# Altium schematic sheet ESD-OP.SchDoc(ESD-OP)
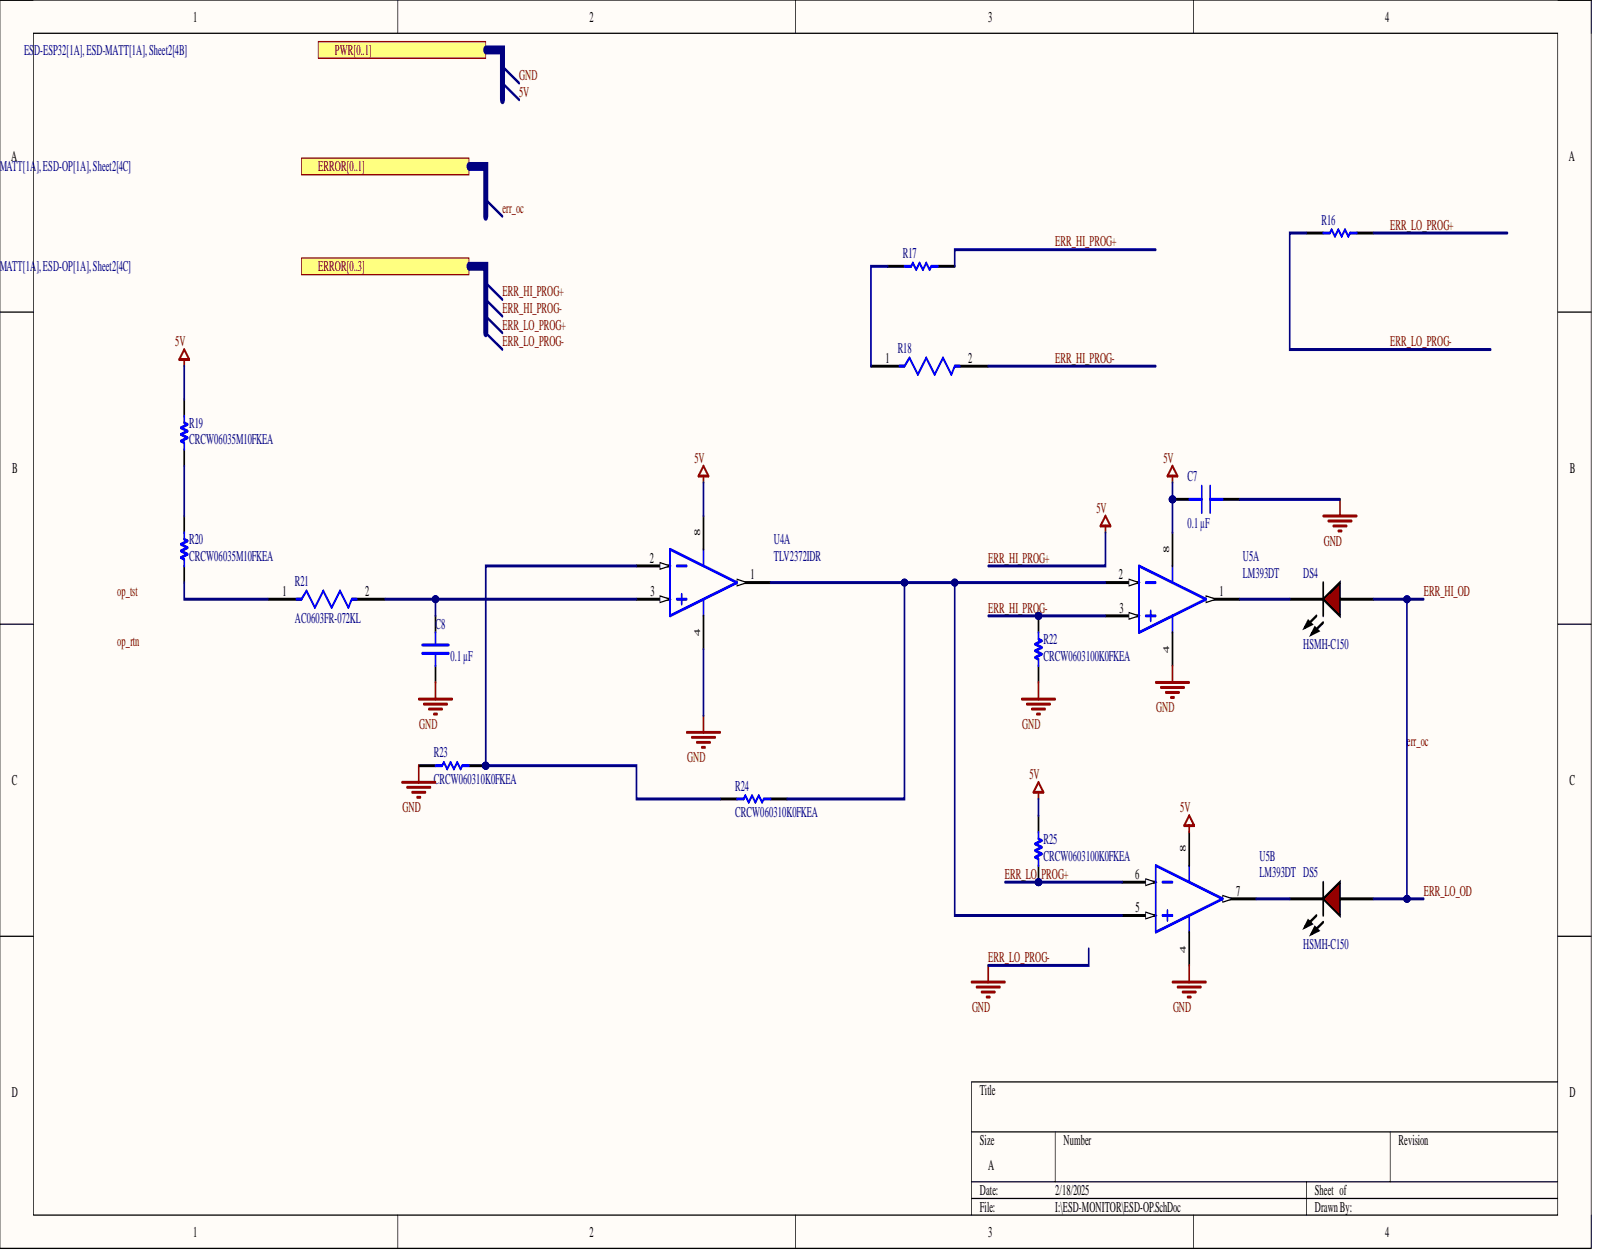
[diagram p0: ESD-OP.SchDoc]

## Parts
C7  0.1 µF  [CAP 0603_1608]
C8  0.1 µF  [CAP 0603_1608]
DS4  HSMH-C150  [AVAG-HSMX-C150_V]
DS5  HSMH-C150  [AVAG-HSMX-C150_V]
R16  CRCW060310K0FKEA  [RESC1609X50X30NL8T20]
R17  CRCW060310K0FKEA  [RESC1609X50X30NL8T20]
R18  AC0603FR-072KL  [FP-AC0603-IPC_B]
R19  CRCW06035M10FKEA  [RESC1609X50X30NL10T20]
R20  CRCW06035M10FKEA  [RESC1609X50X30NL10T20]
R21  AC0603FR-072KL  [FP-AC0603-IPC_B]
R22  CRCW0603100K0FKEA  [RESC1609X50X30NL8T20]
R23  CRCW060310K0FKEA  [RESC1609X50X30NL8T20]
R24  CRCW060310K0FKEA  [RESC1609X50X30NL8T20]
R25  CRCW0603100K0FKEA  [RESC1609X50X30NL8T20]
U4  TLV2372IDR  [D0008A_M]  (part A)
U5  LM393DT  [STM-SO-8_D_L]  (parts A,B)

## Ports
ERROR0, ERROR1, ERROR2, ERROR3, ERROR[0..1], ERROR[0..3], PWR0, PWR1, PWR[0..1]

## Nets
5V: C7.2 R17.2 R19.2 R25.1 U4.8 U5.8
ERR_HI_OD: DS4.1 DS5.1
ERR_HI_PROG-: R18.2 R22.1 U5.3
ERR_LO_PROG+: R16.2 R25.2 U5.6
ERR_LO_PROG-: C7.1 C8.1 R16.1 R22.2 R23.1 U4.4 U5.4
NetC8_2: C8.2 R21.2 U4.3
NetDS4_2: DS4.2 U5.1
NetDS5_2: DS5.2 U5.7
NetR17_1: R17.1 R18.1
NetR19_1: R19.1 R20.2
NetR20_1: R20.1 R21.1
NetR23_2: R23.2 R24.1 U4.2
NetR24_2: R24.2 U4.1 U5.2 U5.5
N00002_0: ; port ERROR[0..1], port ERROR[0..3]
N00002_1: ; port ERROR[0..1], port ERROR[0..3]
N00002_[0..1]: ; port ERROR[0..1]
N00006_2: ; port ERROR[0..3]
N00006_3: ; port ERROR[0..3]
N00006_[0..3]: ; port ERROR[0..3]
N00008_0: ; port PWR[0..1]
N00008_1: ; port PWR[0..1]
op_rtn:
op_tst:

## Library data of the parts
C7 C8 = C0603X104J5RACAUTO: CAP CER 0.1UF 50V X7R 0603; manufacturer: KEMET; Database Table Name: Capacitors - Ceramic - 0603; Tolerance: ±5%; Applications: Automotive, Boardflex Sensitive; Capacitance: 0.1 µF; Component Kind: Standard; componentlink1description: Datasheet; componentlink1url: https://api.kemet.com/component-edge/download/specsheet/C0603X104J5RACAUTO.pdf; componentlink2description: DigiKey Link; componentlink2url: https://www.digikey.com/en/products/detail/kemet/C0603X104J5RACAUTO/3314905; Device Package: 0603 (1608 Metric); Features: Soft Termination; lastupdated: 2/9/2024 8:10:26 PM; Library Name: Celestial Altium Library - altium_library.DbLib; Lifecycle Status: Active; Minimum Order: 1; Mounting Type: Surface Mount, MLCC; Operating Temperature: -55°C ~ 125°C; Package / Case: 0603 (1608 Metric); packaging: TapeAndReel; Part Number: C0603X104J5RACAUTO; Part Status: Active; partid: 40909; price: 0.12; Ratings: AEC-Q200; series: SMD Auto X7R Flex; Size / Dimension: 0.063" L x 0.031" W (1.60mm x 0.80mm); Supplier 1: DigiKey; Supplier Part Number 1: 399-6910-1-ND; Temperature Coefficient: X7R; Thickness (Max): 0.037" (0.95mm); Value: 0.1 µF; Voltage - Rated: 50V
DS4 DS5 = CMP-2000-06426-1: LED Uni-Color Red, 65 mW, 25 mA, -40 to 85 degC, 2-Pin SMD, RoHS, Tape and Reel; Manufacturer: Avago; Chip Material: GaAlAs; DELETED: Compliant; Dominant Wave Length: 639nm; Forward Current: 25mA; Forward Voltage: 2.6V; High Brightness: No; Lens Type: ColorlessDiffused; Lum Intensity: 17mcd; Main Category: ChipLED; Number of  Elements: 1; Peak Wavelength: 660nm; Power Dissipation: 78W; Product Depth (mm): 1.6mm; Product Height (mm): 1.1mm; Product Length (mm): 3.2mm; Reverse Voltage: 5V; Shape Type: Rectangular; Test Current (It): 20mA; Viewing Angle: 170deg; Component Kind: Standard; Datasheet URL: http://media.digikey.com/pdf/Data%20Sheets/Avago%20PDFs/HSMz-Czzz.pdf; Datasheet Version: 03/2012; Emitting Color: Red; Lens Dimensions: 2x1.6x0.6mm; Library Name: Altium Content Vault; Luminous Intensity: 17mcd; Manufacturer URL: http://www.avagotech.com/; Mounting: SurfaceMount; Mounting Technology: SurfaceMount; Operating Temp Range: -40Cto85C; Operating Temperature Classification: Industrial; Package Description: 2-Pin Surface Mount Device, Body 3.2 x 1.6 mm; Package Reference: HSMX-C150; Package Type: ChipLed; Packaging: TapeandReel; Pin Count: 2; Rad Hardened: No; Type: Uni-Color
R16 R17 R23 R24 = CMP-1666-00005-4: Chip Resistor, 10 KOhm, +/- 1%, 100 mW, -55 to 155 degC, 0603 (1608 Metric), RoHS, Tape and Reel; Manufacturer: Vishay Semiconductor; Voltage Rating: 75 V; Component Kind: Standard; ComponentLink1Description: Manufacturer URL; ComponentLink1URL: http://www.vishay.com/; ComponentLink2Description: Datasheet; ComponentLink2URL: https://datasheet.ciiva.com/11809/0900766b80d98afc-11809037.pdf; DatasheetVersion: 06/2012; Height: 0.45 mm; Length: 1.55 mm; Library Name: Altium Content Vault; Max Operating Temperature: 155 degC; Min Operating Temperature: -55 degC; Mounting Technology: Surface Mount; Package Quantity: 5000; PackageDescription: 2-Pin Surface Mount Device, Body 1.575 x 0.85 mm; PackageReference: 0603; Packaging: Tape and Reel; REACH SVHC: Unknown; RoHSCompliant: true
R18 R21 = CMP-2000-04836-2: RES 2kΩ 1.00% 0.1W 0603; Max Power Dissipation: 100mW; Resistance: 2kR; Temperature Coefficient: 100ppm/°C; Tolerance: 1%; Voltage Rating: 50V; Case Code (Imperial): 0603; Case Code (Metric): 1608; Case/Package: 0603; Component Kind: Standard; Construction: Rectangular; Depth: 0.8mm; Features: Moisture Resistant; Height: 0.45mm; Lead Free: Lead Free; Length: 1.6mm; Library Name: Altium Content Vault; Max Operating Temperature: 155°C; Military Standard: Not; Min Operating Temperature: -55°C; Number of Terminations: 2; Packaging: Tape and Reel; Power Rating: 100mW; Ratings: AEC-Q200; Resistor Type: Thick Film; Series: AC; Size Code: 0603; Termination: SMD/SMT; Width: 0.8mm
R19 R20 = CMP-2000-03491-1: Manufacturer: Vishay Dale; Component Kind: Standard; Library Name: Altium Content Vault; Part Number: CRCW06035M10FKEA
R22 R25 = CMP-1666-00005-4: Chip Resistor, 100 KOhm, +/- 1%, 100 mW, -55 to 155 degC, 0603 (1608 Metric), RoHS, Tape and Reel; Manufacturer: Vishay Semiconductor; Voltage Rating: 75 V; Component Kind: Standard; ComponentLink1Description: Manufacturer URL; ComponentLink1URL: http://www.vishay.com/; ComponentLink2Description: Datasheet; ComponentLink2URL: https://datasheet.ciiva.com/11809/0900766b80d98afc-11809037.pdf; DatasheetVersion: 06/2012; Height: 0.45 mm; Length: 1.55 mm; Library Name: Altium Content Vault; Max Operating Temperature: 155 degC; Min Operating Temperature: -55 degC; Mounting Technology: Surface Mount; Package Quantity: 5000; PackageDescription: 2-Pin Surface Mount Device, Body 1.575 x 0.85 mm; PackageReference: 0603; Packaging: Tape and Reel; REACH SVHC: Unknown; RoHSCompliant: true
U4 = CMP-0272-02948-3: 550 uA/Ch, 3 MHz, RRIO Operational Amplifier, 2.7 to 16 V, -40 to 125 degC, 8-pin SOIC (D8), Green (RoHS & no Sb/Br); Manufacturer: Texas Instruments; CMRR(Min)(dB): 50; GBW(Typ)(MHz): 3; IIB(Max)(pA): 60; Iq per channel(Max)(mA): 0.9; Number of Channels: 2; Packing: LARGE T&R; Rating: Catalog; Slew Rate(Typ)(V/us): 2.4; Sub Family: Low Supply Voltage Amplifier (<=2.7V), Precision Amplifier; Total Supply Voltage(Max)(+5V is 5, +/-5V is 10): 16; Total Supply Voltage(Min)(+5V is 5, +/-5V is 10): 2.7; Vio (25C)(Max)(mV): 4.5; Code_JEDEC: MS-012; Component Kind: Standard; ComponentLink1Description: Manufacturer URL; ComponentLink1URL: http://www.ti.com/; ComponentLink2Description: Datasheet; ComponentLink2URL: http://www.ti.com/lit/ds/symlink/tlv2372.pdf; ComponentLink3Description: Package Specification; ComponentLink3URL: http://www.ti.com/litv/pdf/msoi002j; DatasheetVersion: SLOS270D; Eco-Plan: Green (RoHS & no Sb/Br); Library Name: Altium Content Vault; Mounting Technology: Surface Mount; Offset Drift(Typ)(uV/C): 2; PackageDescription: 8-Pin Plastic Small Outline, Body 4.9 x 3.9 mm, Pitch 1.27 mm; PackageReference: D0008A; PackageVersion: 4040047-3/M, 06/2011; PartNumber: TLV2372IDR
U5 = CMP-2000-06550-1: Low-Power, Dual-Voltage Comparator, 2 to 36 V, 0 to 70 degC, 8-Pin SOIC (SO), RoHS, Tape and Reel; Manufacturer: STMicroelectronics; Current - Input Bias (Max): 0.25µA@5V; Current - Output (Typ): 18mA@5V; Current - Quiescent (Max): 2.5mA; EDA / CAD Models: DownloadfromAcceleratedDesigns; Number of Elements: 2; Online Catalog: Comparators-2elements; Other Names: 497-1593-2; Other Related Documents: LM393ViewAllSpecificationsLM393ViewAllSpecifications; Output Type: CMOS,DTL,ECL,MOS,Open-Collector,TTL; Voltage - Input Offset (Max): 5mV@30V; Voltage - Supply, Single/Dual (±): 2V~36V,±1V~18V; Category: IntegratedCircuits(ICs); Component Kind: Standard; Datasheet URL: http://www.st.com/content/ccc/resource/technical/document/datasheet/group1/b4/cc/cf/13/18/28/44/f5/CD00000465/files/CD00000465.pdf/jcr:content/translations/en.CD00000465.pdf; Datasheet Version: Rev. 15, 02/2016; Family: Linear-Comparators; Library Name: Altium Content Vault; Manufacturer URL: http://www.st.com/; Mounting Technology: SurfaceMount; Mounting Type: SurfaceMount; Operating Temperature: 0°C~70°C; Package / Case: 8-SOIC(0.154",3.90mmWidth); Package Description: 8-Pin Small Outline Integrated Circuit, Body 4.9 x 3.9 mm, Pitch 1.27 mm; Package Reference: SO-8; Packaging: Tape&Reel(TR); Standard Package: 2,500; Supplier Device Package: 8-SO; Type: GeneralPurpose
